# Altium schematic sheet POWER.SchDoc(POWER)
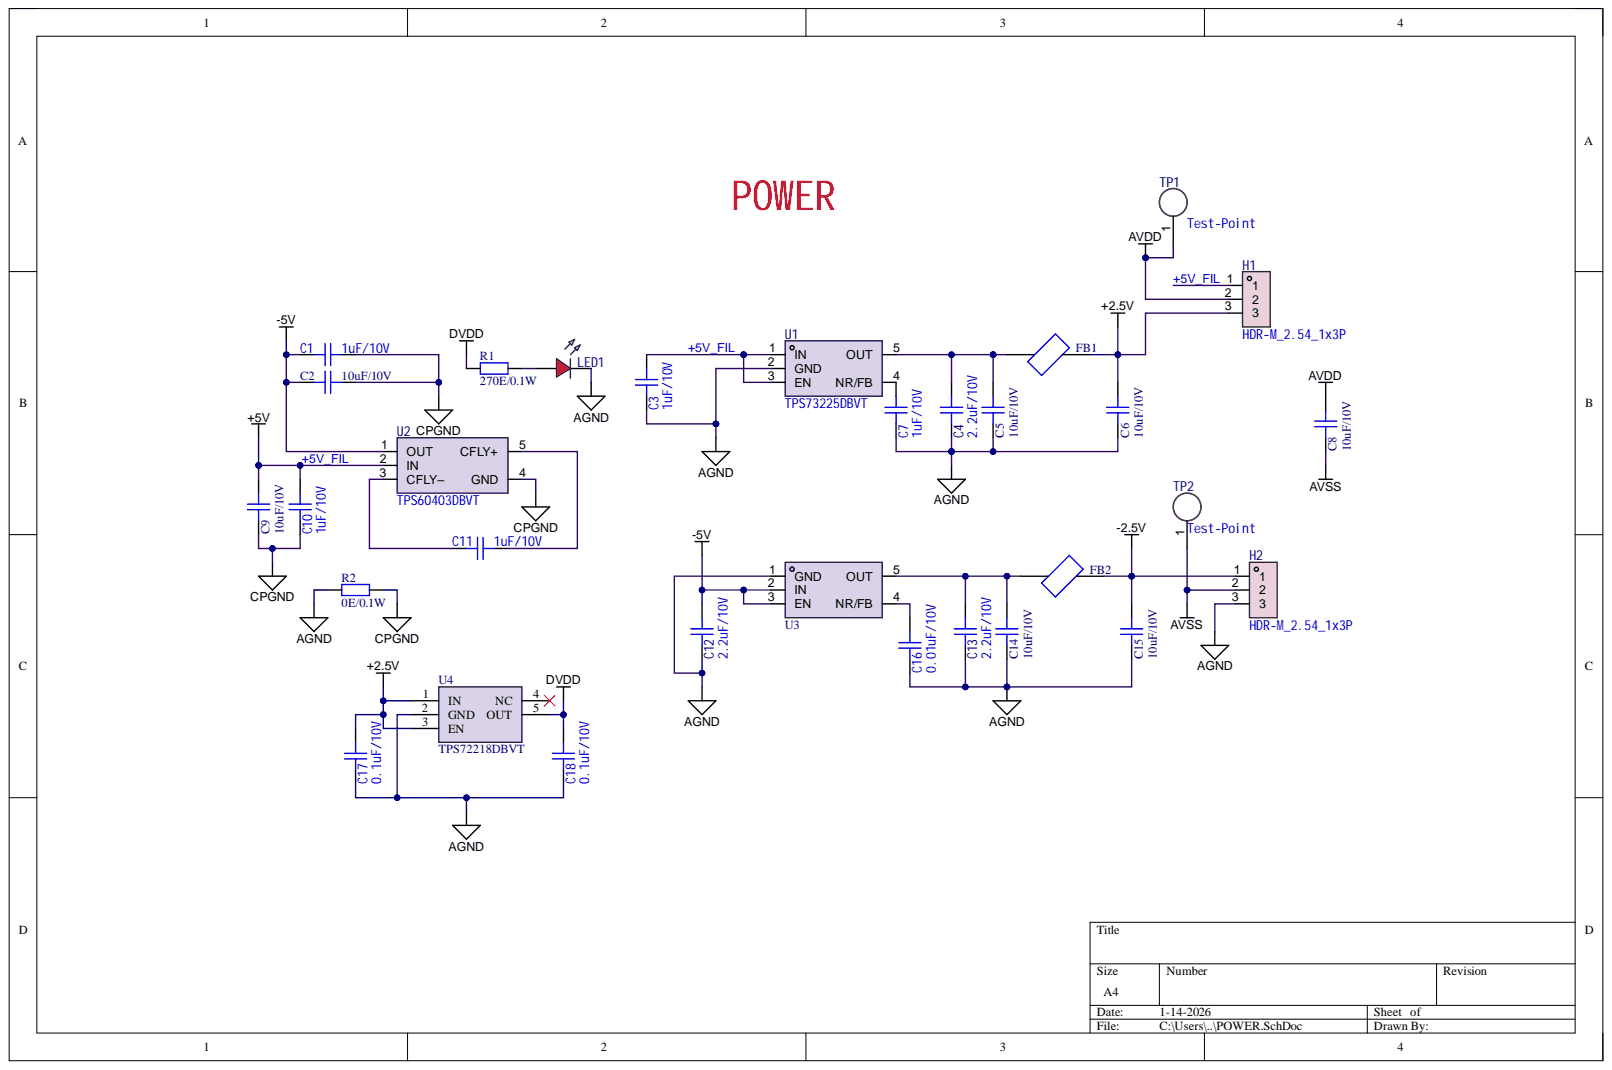
[diagram p0: POWER.SchDoc]

## Parts
C1  value 1uF/10V  [C0603]  (hidden comment: C0603C105K8RACAUTO7411)
C2  value 10uF/10V  [c0603]  (hidden comment: GRM188Z71A106KA73D)
C3  value 1uF/10V  [C0603]  (hidden comment: C0603C105K8RACAUTO7411)
C4  2.2uF  value 2.2uF/10V  [C0603]
C5  value 10uF/10V  [c0603]  (hidden comment: GRM188Z71A106KA73D)
C6  value 10uF/10V  [c0603]  (hidden comment: GRM188Z71A106KA73D)
C7  value 1uF/10V  [C0603]  (hidden comment: C0603C105K8RACAUTO7411)
C8  value 10uF/10V  [c0603]  (hidden comment: GRM188Z71A106KA73D)
C9  value 10uF/10V  [c0603]  (hidden comment: GRM188Z71A106KA73D)
C10  value 1uF/10V  [C0603]  (hidden comment: C0603C105K8RACAUTO7411)
C11  value 1uF/10V  [C0603]  (hidden comment: C0603C105K8RACAUTO7411)
C12  2.2uF  value 2.2uF/10V  [C0603]
C13  2.2uF  value 2.2uF/10V  [C0603]
C14  value 10uF/10V  [c0603]  (hidden comment: GRM188Z71A106KA73D)
C15  value 10uF/10V  [c0603]  (hidden comment: GRM188Z71A106KA73D)
C16  0.01uF  value 0.01uF/10V  [C0603]
C17  0.1uF  value 0.1uF/10V  [C0603]
C18  0.1uF  value 0.1uF/10V  [C0603]
FB1  BMC-Q1JY0026H  [L0603]  (value not drawn)
FB2  BMC-Q1JY0026H  [L0603]  (value not drawn)
H1  HDR-M_2.54_1x3P  [HDR-TH_3P-P2.54-V-M]
H2  HDR-M_2.54_1x3P  [HDR-TH_3P-P2.54-V-M]
LED1  150080SS75000  [LED0805-R-RD]  (value not drawn)
R1  value 270E/0.1W  [R0603]  (hidden comment: CRG0603F270R)
R2  value 0E/0.1W  [r0603]  (hidden comment: RC0603JR-070RL)
TP1  Test-Point  [Test-Point-0.5mm]
TP2  Test-Point  [Test-Point-0.5mm]
U1  TPS73225DBVT  [SOT-23-5_L2.9-W1.6-P0.95-LS2.8-BL]
U2  TPS60403DBVT  [SOT-23-5_L2.9-W1.6-P0.95-LS2.8-BR]
U3  TPS72325QDBVRQ1  [SOT-23-5_L2.9-W1.6-P0.95-LS2.8-BR]  (value not drawn)
U4  TPS72218DBVT  [SOT-23]

## Nets
+2.5V: C6.1 C17.1 FB1.2 H1.3 U4.1(IN) U4.3(EN)
-2.5V: C15.1 FB2.2 H2.1
-5V: C1.2 C2.2 C12.1 U2.1(OUT) U3.2(IN) U3.3(EN)
AGND: C3.2 C4.2 C5.2 C6.2 C7.2 C12.2 C13.2 C14.2 C15.2 C16.2 C17.2 C18.2 H2.3 LED1.2 R2.1 U1.2(GND) U3.1(GND) U4.2(GND)
AVDD: C8.1 H1.2 TP1.1
AVSS: C8.2 H2.2 TP2.1
CPGND: C1.1 C2.1 C9.2 C10.2 R2.2 U2.4(GND)
DVDD: C18.1 R1.1 U4.5(OUT)
+5V_FIL: C3.1 C9.1 C10.1 H1.1 U1.1(IN) U1.3(EN) U2.2(IN)
NetC4_1: C4.1 C5.1 FB1.1 U1.5(OUT)
NetC7_1: C7.1 U1.4(NR/FB)
NetC11_1: C11.1 U2.5(CFLY+)
NetC11_2: C11.2 U2.3(CFLY–)
NetC13_1: C13.1 C14.1 FB2.1 U3.5(OUT)
NetC16_1: C16.1 U3.4(NR/FB)
NetLED1_1: LED1.1 R1.2

Not connected: U4 4(NC)

## Library data of the parts
C1 C3 C7 C10 C11 = C0603C105K8RACAUTO7411: Multilayer Ceramic Capacitors MLCC - SMD/SMT 10 Vol 1 uF 0603 X7R 10 % AECQ200; Add into BOM: yes; Device: CAP_0603; Symbol: CAP; Component Kind: Standard; ComponentLink1Description: Product Link; ComponentLink1URL: https://www.mouser.in/ProductDetail/KEMET/C0603C105K8RACAUTO7411?qs=55YtniHzbhDsN9XQhinV0w%3D%3D; Convert to PCB: yes; Library Name: US00001.SCHLIB; Supplier Footprint: 0603
C2 C5 C6 C8 C14 C15 = GRM188Z71A106KA73D: Multilayer Ceramic Capacitors MLCC - SMD/SMT 10 uF 10 VDC 10% 0603 X7R; Component Kind: Standard; ComponentLink1Description: Product link; ComponentLink1URL: https://www.mouser.in/ProductDetail/Murata-Electronics/GRM188Z71A106KA73D?qs=d0WKAl%252BL4KaRM1L%252BaIs%2FqQ%3D%3D; Library Name: US00001.SCHLIB
C4 C12 C13 = GRM188R71A225KE15J: Multilayer Ceramic Capacitors MLCC - SMD/SMT 2.2 uF 10 VDC 10% 0603 X7R; Add into BOM: yes; Device: CAP_0603; Symbol: CAP; Component Kind: Standard; ComponentLink1Description: Product link; ComponentLink1URL: https://www.mouser.in/ProductDetail/Murata-Electronics/GRM188R71A225KE15J?qs=UOvNgVTuGCp28jccFPFZuA%3D%3D; Convert to PCB: yes; Library Name: US00001.SCHLIB; Supplier Footprint: 0603
C9 = GRM188Z71A106KA73D: Multilayer Ceramic Capacitors MLCC - SMD/SMT 10 uF 10 VDC 10% 0603 X7R; Value: 10uF/10V; Component Kind: Standard; ComponentLink1Description: Product link; ComponentLink1URL: https://www.mouser.in/ProductDetail/Murata-Electronics/GRM188Z71A106KA73D?qs=d0WKAl%252BL4KaRM1L%252BaIs%2FqQ%3D%3D; Library Name: US00001.SCHLIB
C16 = C0603C103K8RALTU: Multilayer Ceramic Capacitors MLCC - SMD/SMT 10V 0.01uF 0603 X7R 0.1; Add into BOM: yes; Device: CAP_0603; Symbol: CAP; Component Kind: Standard; ComponentLink1Description: Product link; ComponentLink1URL: https://www.mouser.in/ProductDetail/KEMET/C0603C103K8RALTU?qs=3icvQwKc1bVHJBAUrmvERQ%3D%3D; Convert to PCB: yes; Library Name: US00001.SCHLIB; Supplier Footprint: 0603
C17 C18 = CC0603KRX7R6BB104: CAP CER 0.1UF 10V X7R 0603; Add into BOM: yes; Device: CAP_0603; Component Kind: Standard; ComponentLink1Description: Product link; ComponentLink1URL: https://www.digikey.in/en/products/detail/yageo/CC0603KRX7R6BB104/5883741; Convert to PCB: yes; Library Name: US00001.SCHLIB; Supplier Footprint: 0603; Symbol: CAP
FB1 FB2 = BMC-Q1JY0026H: Ferrite Beads BMC-Q 0603 26R 25% Tol. High Current; Component Kind: Standard; ComponentLink1Description: Product Link; ComponentLink1URL: https://www.mouser.in/ProductDetail/TE-Connectivity-Sigma-Inductors/BMC-Q1JY0026H?qs=IKkN%2F947nfDoVp1a%2FqGVzA%3D%3D; ComponentLink2Description: Datasheet Link; ComponentLink2URL: https://www.te.com/commerce/DocumentDelivery/DDEController?Action=showdoc&DocId=Data+Sheet%7F1773178-3%7FA1%7Fpdf%7FEnglish%7FENG_DS_1773178-3_A1.pdf%7F2176742-3; Library Name: US00001.SCHLIB
H1 H2 = HDR-M_2.54_1x3P: Add into BOM: yes; Symbol: PZ254V-11-03P_C2937625; Component Kind: Standard; Convert to PCB: yes; Device: HDR-M_2.54_1x3P; Library Name: US00001.SCHLIB; Supplier Footprint: Through
LED1 = 150080SS75000: LED RED CLEAR 0805 SMD; Add into BOM: yes; Device: LED_0805-R; Symbol: LED_R; Component Kind: Standard; ComponentLink1Description: Product link; ComponentLink1URL: https://www.digikey.in/en/products/detail/w%C3%BCrth-elektronik/150080SS75000/4489921; Convert to PCB: yes; Library Name: US00001.SCHLIB; Supplier Footprint: 0805
R1 = CRG0603F270R: RES SMD 270 OHM 1% 1/10W 0603; Component Kind: Standard; ComponentLink1Description: Product link; ComponentLink1URL: https://www.digikey.in/en/products/detail/te-connectivity-passive-product/CRG0603F270R/2380754; Library Name: US00001.SCHLIB
R2 = RC0603JR-070RL: RES 0 OHM JUMPER 1/10W 0603; Component Kind: Standard; ComponentLink1Description: Product link; ComponentLink1URL: https://www.digikey.com/en/products/detail/yageo/RC0603JR-070RL/726675; Library Name: US00001.SCHLIB
TP1 TP2 = Test-Point: Add into BOM: yes; Component Kind: Standard; Convert to PCB: yes; Device: Test-Point; Library Name: US00001.SCHLIB; Symbol: Test-Point
U1 = TPS73225DBVT: IC REG LINEAR 2.5V 250MA SOT23-5; Manufacturer: TI(德州仪器); Add into BOM: yes; Number of Outputs: 1; Output Configuration: Positive electrode; Output Type: Fixed; Voltage - Supply: 5.5V; Component Kind: Standard; ComponentLink1Description: Product link; ComponentLink1URL: https://www.digikey.in/en/products/detail/texas-instruments/TPS73225DBVT/604395; ComponentLink2Description: Datasheet link; ComponentLink2URL: https://www.ti.com/lit/ds/symlink/tps732.pdf?ts=1759479960321; Convert to PCB: yes; Datasheet: https://www.ti.com/cn/lit/gpn/tps732; Device: TPS73225DBVT; Features: ESD Protection；Overvoltage Protection；Low ESR；Overheat protection；Overcurrent Protection；With ability；With Charge Pump；Anti-Siphon; JLCPCB Part Class: Extended Part; LCSC Part Name: 具有反向电流保护和使能功能的 250mA、高精度、超低压降稳压器; Library Name: US00001.SCHLIB; Manufacturer Part: TPS73225DBVT; Noise: -; Operating Temperature: -40℃~+125℃; Output Current: 250mA; Output Voltage: 2.5V; Power Supply Rejection Ratio (PSRR): -; standby current: -; Supplier: LCSC; Supplier Footprint: SOT-23-5; Supplier Part: C2862348; Symbol: TPS73225DBVT; Voltage Dropout: -
U2 = TPS60403DBVT: IC REG CHG PUMP INV 60MA SOT23-5; Manufacturer: TI(德州仪器); Add into BOM: yes; Function: Inverted; Operating Voltage: 1.8V~5.25V; Output Resistance: 12Ω; PFM Mode: Not Supported; Quiescent Current: 700uA; Switching Frequency: 250kHz; Component Kind: Standard; ComponentLink1Description: Product link; ComponentLink1URL: https://www.digikey.in/en/products/detail/texas-instruments/TPS60403DBVT/484639; ComponentLink2Description: Datasheet link; ComponentLink2URL: https://www.ti.com/lit/ds/symlink/tps60400.pdf?HQS=dis-dk-null-digikeymode-dsf-pf-null-wwe&ts=1767946235607&ref_url=https%253A%252F%252Fwww.ti.com%252Fgeneral%252Fdocs%252Fsuppproductinfo.tsp%253FdistId%253D10%2526gotoUrl%253Dhttps%253A%252F%252Fwww.ti.com%252Flit%252Fgpn%252Ftps60400; Convert to PCB: yes; Datasheet: https://www.ti.com/cn/lit/gpn/tps60401; Device: TPS60403DBVT; JLCPCB Part Class: Extended Part; LCSC Part Name: 非稳压60mA电荷泵电压逆变器; Library Name: US00001.SCHLIB; Manufacturer Part: TPS60403DBVT; Operating Temperature: -40℃~+125℃; Output Current: 60mA; Supplier: LCSC; Supplier Footprint: SOT-23-5; Supplier Part: C2070720; Symbol: TPS60403DBVT
U3 = TPS72325QDBVRQ1: IC REG LIN -2.5V 200MA SOT23-5; Component Kind: Standard; ComponentLink1Description: Product link; ComponentLink1URL: https://www.digikey.in/en/products/detail/texas-instruments/TPS72325QDBVRQ1/7688256; Library Name: US00001.SCHLIB
U4 = TPS72218DBVT: IC REG LINEAR 1.8V 50MA SOT23-5; Component Kind: Standard; ComponentLink1Description: Product Link; ComponentLink1URL: https://www.digikey.in/en/products/detail/texas-instruments/TPS72218DBVT/432085; ComponentLink2Description: Datasheet Link; ComponentLink2URL: https://www.ti.com/lit/ds/slvs390b/slvs390b.pdf; Library Name: US00001.SCHLIB
